# Altium schematic sheet Keyboard.SchDoc(Keyboard)
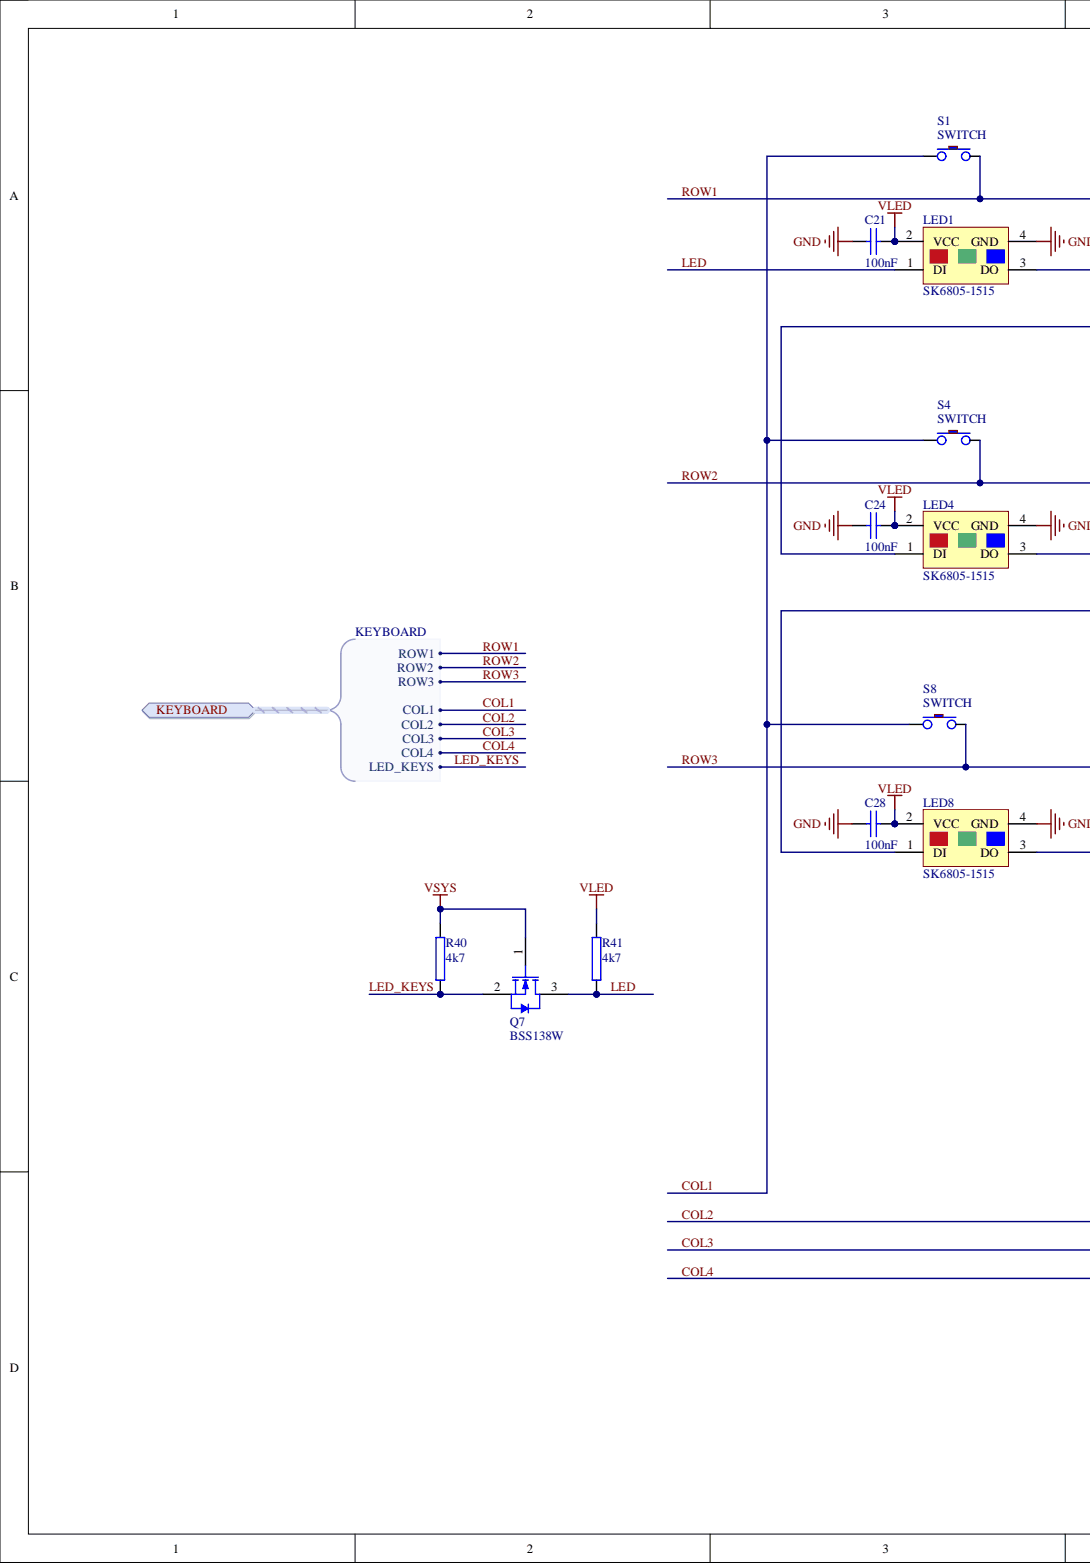
[diagram p0: Keyboard.SchDoc, left]
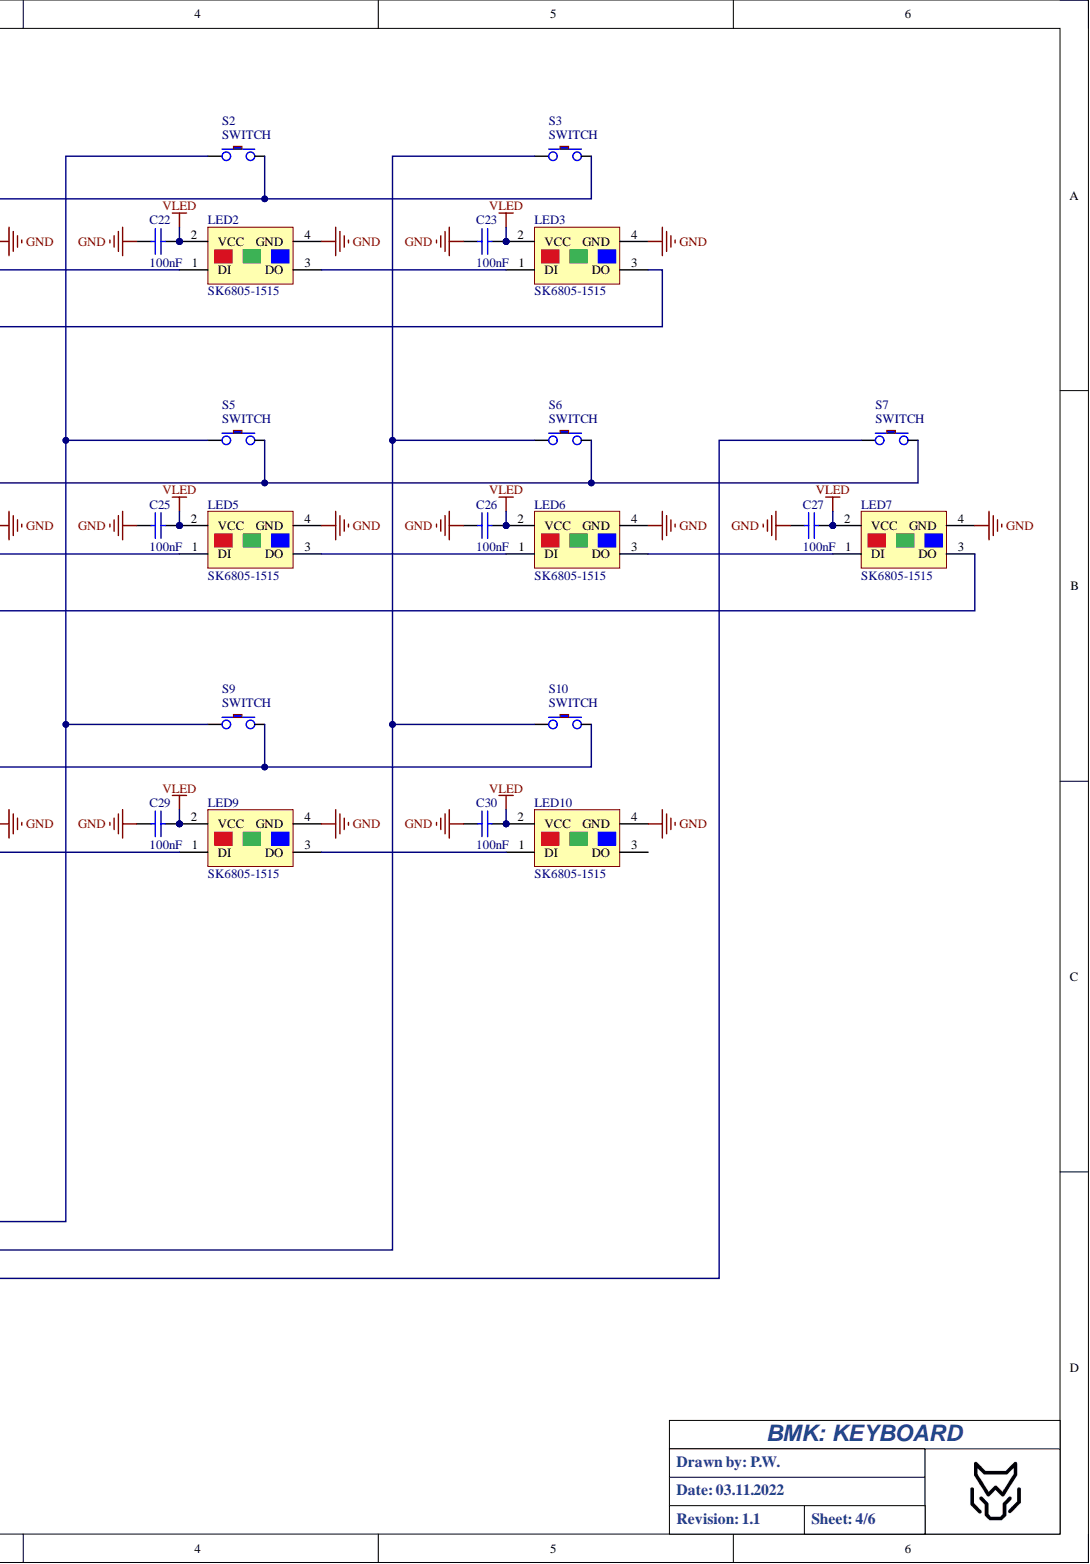
[diagram p1: Keyboard.SchDoc, right]

## Parts
C21  100nF  [C0603L]
C22  100nF  [C0603L]
C23  100nF  [C0603L]
C24  100nF  [C0603L]
C25  100nF  [C0603L]
C26  100nF  [C0603L]
C27  100nF  [C0603L]
C28  100nF  [C0603L]
C29  100nF  [C0603L]
C30  100nF  [C0603L]
LED1  SK6805-1515  [SK6805-1515]
LED2  SK6805-1515  [SK6805-1515]
LED3  SK6805-1515  [SK6805-1515]
LED4  SK6805-1515  [SK6805-1515]
LED5  SK6805-1515  [SK6805-1515]
LED6  SK6805-1515  [SK6805-1515]
LED7  SK6805-1515  [SK6805-1515]
LED8  SK6805-1515  [SK6805-1515]
LED9  SK6805-1515  [SK6805-1515]
LED10  SK6805-1515  [SK6805-1515]
Q7  BSS138W  [SOT-323]
R40  4k7  [1608[0603]]
R41  4k7  [1608[0603]]
S1  SWITCH  [KAILH SWITCH]
S2  SWITCH  [KAILH SWITCH]
S3  SWITCH  [KAILH SWITCH]
S4  SWITCH  [KAILH SWITCH]
S5  SWITCH  [KAILH SWITCH]
S6  SWITCH  [KAILH SWITCH]
S7  SWITCH  [KAILH SWITCH]
S8  SWITCH  [KAILH SWITCH]
S9  SWITCH  [KAILH SWITCH]
S10  SWITCH  [KAILH SWITCH]

## Ports
KEYBOARD, KEYBOARD.COL1, KEYBOARD.COL2, KEYBOARD.COL3, KEYBOARD.COL4, KEYBOARD.LED_KEYS, KEYBOARD.ROW1, KEYBOARD.ROW2, KEYBOARD.ROW3

## Nets
GND: C21.2 C22.2 C23.2 C24.2 C25.2 C26.2 C27.2 C28.2 C29.2 C30.2 LED1.4(GND) LED2.4(GND) LED3.4(GND) LED4.4(GND) LED5.4(GND) LED6.4(GND) LED7.4(GND) LED8.4(GND) LED9.4(GND) LED10.4(GND)
VLED: C21.1 C22.1 C23.1 C24.1 C25.1 C26.1 C27.1 C28.1 C29.1 C30.1 LED1.2(VCC) LED2.2(VCC) LED3.2(VCC) LED4.2(VCC) LED5.2(VCC) LED6.2(VCC) LED7.2(VCC) LED8.2(VCC) LED9.2(VCC) LED10.2(VCC) R41.2
VSYS: Q7.1 R40.2
COL1: S1.1 S4.1 S8.1 ; port KEYBOARD
COL2: S2.1 S5.1 S9.1 ; port KEYBOARD
COL3: S3.1 S6.1 S10.1 ; port KEYBOARD
COL4: S7.1 ; port KEYBOARD
LED: LED1.1(DI) Q7.3 R41.1
LED_KEYS: Q7.2 R40.1 ; port KEYBOARD
ROW1: S1.2 S2.2 S3.2 ; port KEYBOARD
ROW2: S4.2 S5.2 S6.2 S7.2 ; port KEYBOARD
ROW3: S8.2 S9.2 S10.2 ; port KEYBOARD
NetLED1_3: LED1.3(DO) LED2.1(DI)
NetLED2_3: LED2.3(DO) LED3.1(DI)
NetLED3_3: LED3.3(DO) LED4.1(DI)
NetLED4_3: LED4.3(DO) LED5.1(DI)
NetLED5_3: LED5.3(DO) LED6.1(DI)
NetLED6_3: LED6.3(DO) LED7.1(DI)
NetLED7_3: LED7.3(DO) LED8.1(DI)
NetLED8_3: LED8.3(DO) LED9.1(DI)
NetLED9_3: LED9.3(DO) LED10.1(DI)

Not connected: LED10 3(DO)

## Library data of the parts
C21-C30 = CAP: Capacitor; Component Kind: Standard; Library Name: LIB_PW.IntLib
Q7 = MOSFET N: Component Kind: Standard; Library Name: LIB_PW.IntLib
R40 R41 = RES: Component Kind: Standard; Library Name: LIB_PW.IntLib
LED1-LED10 = SK6805-1515: Component Kind: Standard; Library Name: LIB_PW.IntLib
S1-S10 = SWITCH: Component Kind: Standard; Library Name: LIB_PW.IntLib
